# Altium schematic sheet Module V1.SchDoc("Module V1")
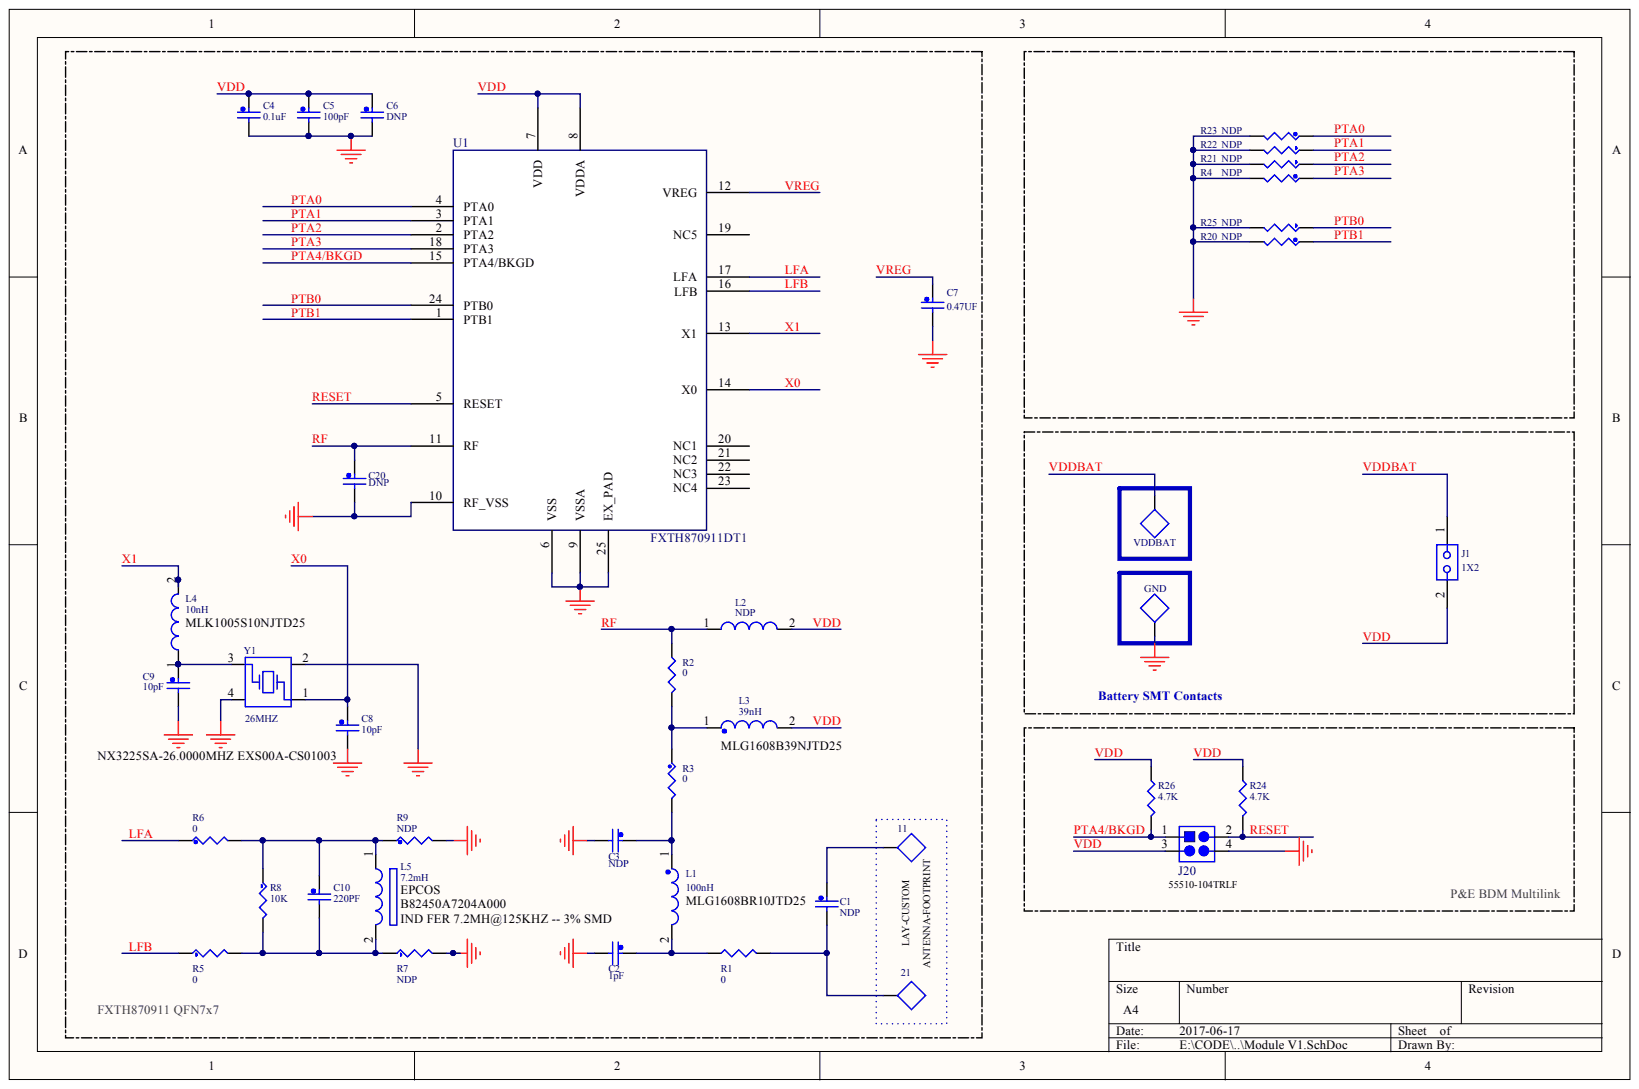
[diagram p0: Module V1.SchDoc]

## Parts
C1  NDP  [0603_C]
C2  1pF  [0603_C]
C3  NDP  [0603_C]
C4  0.1uF  [0603_C]
C5  100pF  [0603_C]
C6  DNP  [0603_C]
C7  0.47UF  [0603_C]
C8  10pF  [0603_C]
C9  10pF  [0603_C]
C10  220PF  [0603_C]
C20  DNP  [0603_C]
J1  1X2  [HDR_2.0_2]
J20  Header_4  [HDR_2.0_2X2]  (value not drawn)
L1  100nH  [0603_L]
L2  NDP  [0603_L]
L3  39nH  [0603_L]
L4  10nH  [0603_L]
L5  7.2mH  [EPCOS_7.2mH]
P1  11  [PIN_1P]
P2  21  [PIN_1P]
P3  VDDBAT  [PIN_1P]
P4  GND  [PIN_1P]
R1  0  [0603_R]
R2  0  [0603_R]
R3  0  [0603_R]
R4  NDP  [0603_R]
R5  0  [0603_R]
R6  0  [0603_R]
R7  NDP  [0603_R]
R8  10K  [0603_R]
R9  NDP  [0603_R]
R20  NDP  [0603_R]
R21  NDP  [0603_R]
R22  NDP  [0603_R]
R23  NDP  [0603_R]
R24  4.7K  [0603_R]
R25  NDP  [0603_R]
R26  4.7K  [0603_R]
U1  FXTH870911DT1  [QFN24]
Y1  26MHZ  [XTAL_3225]

## Nets
GND: C2.2 C3.2 C4.2 C5.2 C6.2 C7.2 C8.2 C9.2 C20.2 J20.4 P4.1 R4.2 R7.2 R9.2 R20.2 R21.2 R22.2 R23.2 R25.2 U1.6(VSS) U1.9(VSSA) U1.10(RF_VSS) U1.25(EX_PAD) Y1.2 Y1.4
LFA: R6.1 U1.17(LFA)
LFB: R5.1 U1.16(LFB)
PTA0: R23.1 U1.4(PTA0)
PTA1: R22.1 U1.3(PTA1)
PTA2: R21.1 U1.2(PTA2)
PTA3: R4.1 U1.18(PTA3)
PTA4/BKGD: J20.1 R26.2 U1.15(PTA4/BKGD)
PTB0: R25.1 U1.24(PTB0)
PTB1: R20.1 U1.1(PTB1)
RESET: J20.2 R24.2 U1.5(RESET)
RF: C20.1 L2.1 R2.1 U1.11(RF)
VDD: C4.1 C5.1 C6.1 J1.2 J20.3 L2.2 L3.2 R24.1 R26.1 U1.7(VDD) U1.8(VDDA)
VDDBAT: J1.1 P3.1
VREG: C7.1 U1.12(VREG)
X0: C8.1 U1.14(X0) Y1.1
X1: L4.2 U1.13(X1)
NetC1_1: C1.1 P1.1
NetC1_2: C1.2 P2.1 R1.2
NetC2_1: C2.1 L1.2 R1.1
NetC3_1: C3.1 L1.1 R3.2
NetC9_1: C9.1 L4.1 Y1.3
NetC10_1: C10.1 L5.1 R6.2 R8.1 R9.1
NetC10_2: C10.2 L5.2 R5.2 R7.1 R8.2
NetL3_1: L3.1 R2.2 R3.1

Not connected: U1 19(NC5) 20(NC1) 21(NC2) 22(NC3) 23(NC4)

## Library data of the parts
C1-C10 C20 = CAP: 贴片电容; Component Kind: Standard; Library Name: Library.IntLib
  C1 C3: Value: NDP
  C2: Value: 1pF
  C4: Value: 0.1uF
  C5: Value: 100pF
  C6 C20: Value: DNP
  C7: Value: 0.47UF
  C8 C9: Value: 10pF
  C10: Value: 220PF
J1 = Header_2: Component Kind: Standard; Library Name: Library.IntLib
L1 L3 = Inductor: 贴片电感; Component Kind: Standard; Library Name: Library.IntLib
  L1: Value: 100nH
  L3: Value: 39nH
L2 L4 = Inductor2: 贴片电感; Component Kind: Standard; Library Name: Library.IntLib
  L2: Value: NDP
  L4: Value: 10nH
L5 = 低频电感: Component Kind: Standard; Description: 低频电感; Library Name: Library.IntLib; Value: 7.2mH
P1-P4 = PIN_1P: Component Kind: Standard; Library Name: Library.IntLib
R1-R9 R20-R26 = RES: 贴片电阻; Component Kind: Standard; Library Name: Library.IntLib
  R1-R3 R5 R6: Value: 0
  R4 R7 R9 R20-R23 R25: Value: NDP
  R8: Value: 10K
  R24 R26: Value: 4.7K
U1 = FXTH87: Component Kind: Standard; Description: FXTH870911DT1; Library Name: Schlib.SchLib
Y1 = XTAL: 晶振; Component Kind: Standard; Library Name: Library.IntLib; Value: 26MHZ
J20 = Header_4: Component Kind: Standard; Library Name: Library.IntLib
